# Altium schematic sheet Power Management.SchDoc
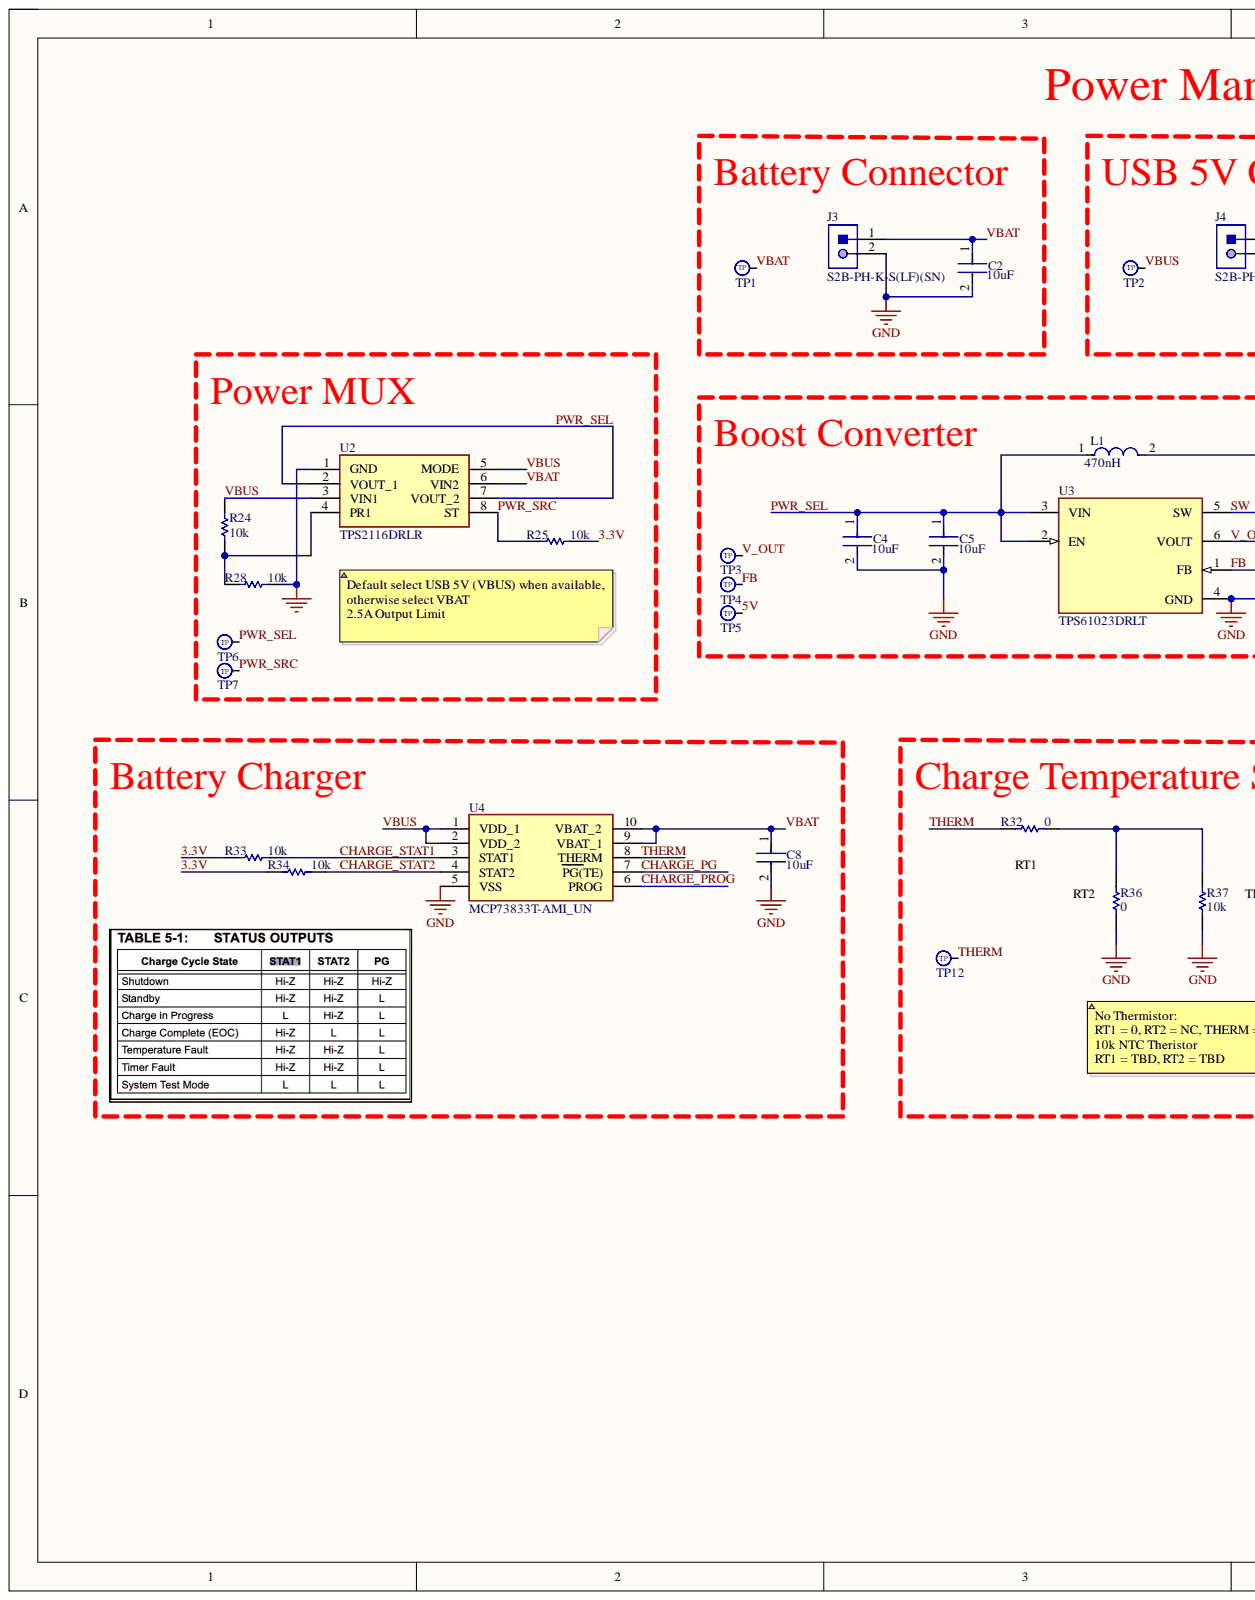
[diagram p0: Power Management.SchDoc, left]
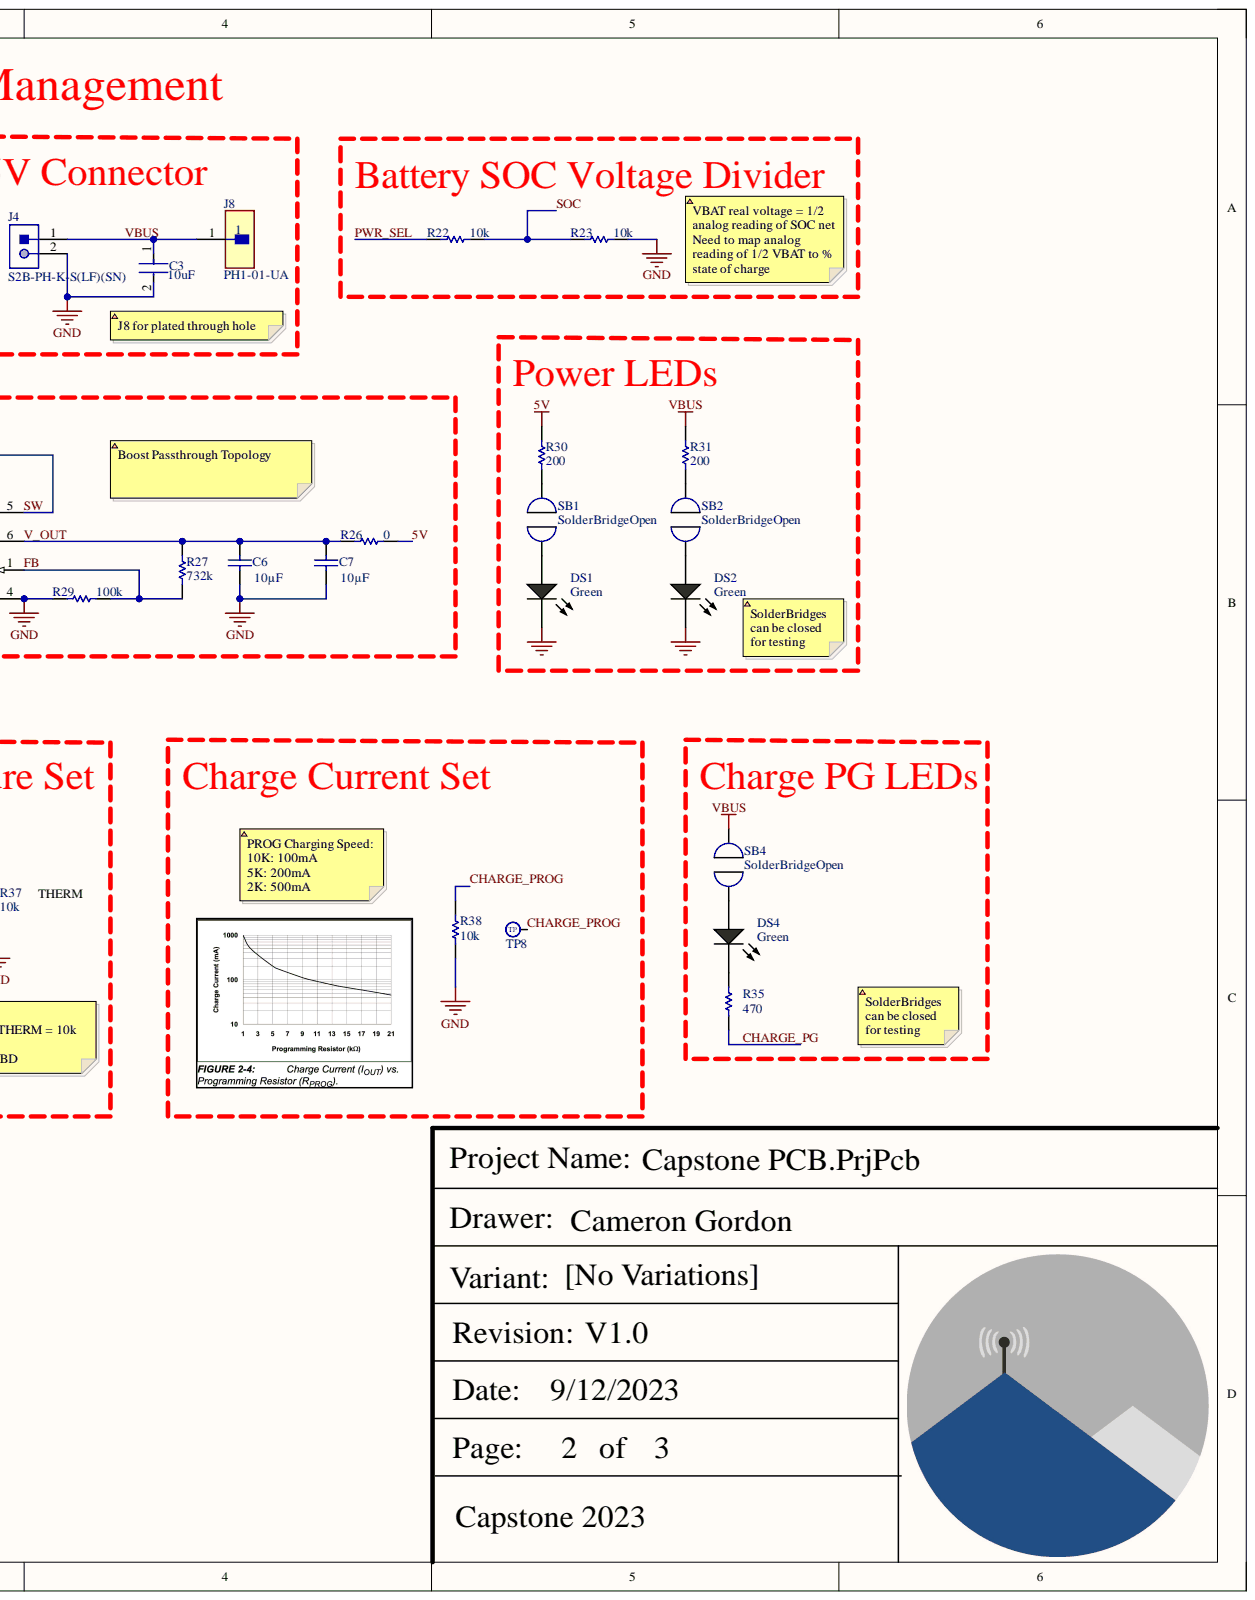
[diagram p1: Power Management.SchDoc, right]

## Parts
C2  value 10uF  Capacitance: 10uF  [FP-C0805C-DG-MFG]  (hidden comment: C0805C106K8PACTU)
C3  value 10uF  Capacitance: 10uF  [FP-C0805C-DG-MFG]  (hidden comment: C0805C106K8PACTU)
C4  value 10uF  Capacitance: 10uF  [FP-C0805C-DG-MFG]  (hidden comment: C0805C106K8PACTU)
C5  value 10uF  Capacitance: 10uF  [FP-C0805C-DG-MFG]  (hidden comment: C0805C106K8PACTU)
C6  Capacitance: 10µF  [CAPC3216X180X55LL20T25]  (hidden comment: GRM31CR71C106KAC7L)
C7  Capacitance: 10µF  [CAPC3216X180X55LL20T25]  (hidden comment: GRM31CR71C106KAC7L)
C8  value 10uF  Capacitance: 10uF  [FP-C0805C-DG-MFG]  (hidden comment: C0805C106K8PACTU)
DS1  Color: Green  [LUMX-SML-LXT0805_V]  (hidden comment: SML-LXT0805GW-TR)
DS2  Color: Green  [LUMX-SML-LXT0805_V]  (hidden comment: SML-LXT0805GW-TR)
DS4  Color: Green  [LUMX-SML-LXT0805_V]  (hidden comment: SML-LXT0805GW-TR)
J3  S2B-PH-K-S(LF)(SN)  [JST-S2B-PH-K-S_V]
J4  S2B-PH-K-S(LF)(SN)  [JST-S2B-PH-K-S_V]
J8  PH1-01-UA  [FP-PH1-01-UA-MFG]
L1  Inductance: 470nH  [FP-IHLP-1212AE-11-MFG]  (hidden comment: IHLP1212AEERR47M11)
R22  Resistance: 10k  [RESC1609X55X30LL15T15]  (hidden comment: ERJ3EKF1002V)
R23  Resistance: 10k  [RESC1609X55X30LL15T15]  (hidden comment: ERJ3EKF1002V)
R24  Resistance: 10k  [RESC1609X55X30LL15T15]  (hidden comment: ERJ3EKF1002V)
R25  Resistance: 10k  [RESC1609X55X30LL15T15]  (hidden comment: ERJ3EKF1002V)
R26  RC0603JR-070RL  [RESC1608X55X25ML10T15]  (value not drawn)
R27  value 732k  [RESC1608X55X30LL15T15]  (hidden comment: ERJ-3EKF5232V)
R28  Resistance: 10k  [RESC1609X55X30LL15T15]  (hidden comment: ERJ3EKF1002V)
R29  value 100k  [RESC1608X55X30ML15T15]  (hidden comment: ERJ-3EKF1003V)
R30  value 200  [RESC2013X60X35ML10T20]  (hidden comment: RC0805JR-07200RL)
R31  value 200  [RESC2013X60X35ML10T20]  (hidden comment: RC0805JR-07200RL)
R32  RC0603JR-070RL  [RESC1608X55X25ML10T15]  (value not drawn)
R33  Resistance: 10k  [RESC1609X55X30LL15T15]  (hidden comment: ERJ3EKF1002V)
R34  Resistance: 10k  [RESC1609X55X30LL15T15]  (hidden comment: ERJ3EKF1002V)
R35  value 470  [RESC1608X55X30NL15T15]  (hidden comment: ERJ-3GEYJ471V)
R36  RC0603JR-070RL  [RESC1608X55X25ML10T15]  (value not drawn)
R37  Resistance: 10k  [RESC1609X55X30LL15T15]  (hidden comment: ERJ3EKF1002V)
R38  Resistance: 10k  [RESC1609X55X30LL15T15]  (hidden comment: ERJ3EKF1002V)
SB1  SolderBridgeOpen  [SOLDERBRIDGEOPEN]
SB2  SolderBridgeOpen  [SOLDERBRIDGEOPEN]
SB4  SolderBridgeOpen  [SOLDERBRIDGEOPEN]
TP1  0.040" Circular Test Point  [40 mil Circular Test Point]  (value not drawn)
TP2  0.040" Circular Test Point  [40 mil Circular Test Point]  (value not drawn)
TP3  0.040" Circular Test Point  [40 mil Circular Test Point]  (value not drawn)
TP4  0.040" Circular Test Point  [40 mil Circular Test Point]  (value not drawn)
TP5  0.040" Circular Test Point  [40 mil Circular Test Point]  (value not drawn)
TP6  0.040" Circular Test Point  [40 mil Circular Test Point]  (value not drawn)
TP7  0.040" Circular Test Point  [40 mil Circular Test Point]  (value not drawn)
TP8  0.040" Circular Test Point  [40 mil Circular Test Point]  (value not drawn)
TP12  0.040" Circular Test Point  [40 mil Circular Test Point]  (value not drawn)
U2  TPS2116DRLR  [SOTFL50P160X60-8N]
U3  TPS61023DRLT  [FP-DRL0006A-MFG]
U4  MCP73833T-AMI_UN  [SOP50P490X110-10N]

## Nets
GND: C2.2 C3.2 C4.2 C5.2 C6.1 C7.1 C8.2 DS1.2 DS2.2 J3.2 J4.2 R23.2 R28.2 R29.1 R36.2 R37.1 R38.1 U2.1(GND) U3.4(GND) U4.5(VSS)
3.3V: R25.2 R33.1 R34.1
5V: R26.1 R30.2 TP5.1
CHARGE_PG: R35.1 U4.7(PG(TE))
CHARGE_PROG: R38.2 TP8.1 U4.6(PROG)
CHARGE_STAT1: R33.2 U4.3(STAT1)
CHARGE_STAT2: R34.2 U4.4(STAT2)
FB: R27.1 R29.2 TP4.1 U3.1(FB)
PWR_SEL: C4.1 C5.1 L1.1 R22.1 TP6.1 U2.2(VOUT_1) U2.7(VOUT_2) U3.2(EN) U3.3(VIN)
PWR_SRC: R25.1 TP7.1 U2.8(ST)
SOC: R22.2 R23.1
SW: L1.2 U3.5(SW)
THERM: R32.2 TP12.1 U4.8(THERM)
V_OUT: C6.2 C7.2 R26.2 R27.2 TP3.1 U3.6(VOUT)
VBAT: C2.1 C8.1 J3.1 TP1.1 U2.6(VIN2) U4.9(VBAT_1) U4.10(VBAT_2)
VBUS: C3.1 J4.1 J8.1 R24.2 R31.2 SB4.2 TP2.1 U2.3(VIN1) U2.5(MODE) U4.1(VDD_1) U4.2(VDD_2)
NetDS1_1: DS1.1 SB1.1
NetDS2_1: DS2.1 SB2.1
NetDS4_1: DS4.1 SB4.1
NetDS4_2: DS4.2 R35.2
NetR24_1: R24.1 R28.1 U2.4(PR1)
NetR30_1: R30.1 SB1.2
NetR31_1: R31.1 SB2.2
NetR32_1: R32.1 R36.1 R37.2

## Library data of the parts
C2-C5 C8 = CMP-2007-03243-2: CAP CER 10UF 10V X5R 0805; 3DS Part Number: 220-00001; Manufacturer: Kemet; Material: Ceramic; Temperature Coefficient: 15%; Tolerance: 10%; Automotive: No; Category: Cap; Component Kind: Standard; ComponentLink2Description: Datasheet; ComponentLink2URL: https://content.kemet.com/datasheets/KEM_C1006_X5R_SMD.pdf; Device Class L1: Passive Components; Device Class L2: Capacitors; Device Class L3: Ceramic Capacitors; Digikey Description: CAP CER 10UF 10V X5R 0805; Height: 1.4mm; Ipc Land Pattern Name: CAPC200125X125; Lead Free: Yes; Library Name: Altium Content Vault; Manufacturer Part Number: C0805C106K8PACTU; Mouser Description: CAP CER 10UF 10V X5R 0805; Octopart: https://octopart.com/c0805c106k8pactu-kemet-98697; Package: C0805C-DG; RoHS: TRUE; Temperature: -55°C to +85°C; Temperature Characteristic: X5R; Temperature Range High: +85°C; Temperature Range Low: -55°C; Voltage: 10V; Voltage Rating: 10V
C6 C7 = CMP-2000-06352-1: Chip Capacitor, 10 uF, +/- 10%, 16 V, -55 to 125 degC, 1206 (3216 Metric), RoHS, Tape and Reel; Manufacturer: Murata; Catalog Drawings: GRMSeries_1206_1.6; Featured Product: GA3SafetyRecognizedCapsandHi-CapMLCC; Online Catalog: GRMSeries; Other Names: 490-3911-6; Product Training Modules: High-CapMultilayerCeramicCapacitors; Temperature Coefficient: X7R; Voltage - Rated: 16V; Applications: GeneralPurpose; Category: Capacitors; Component Kind: Standard; Datasheet URL: http://search.murata.co.jp/Ceramy/image/img/A01X/G101/ENG/GRM31CR71C106KAC7-01.pdf; Datasheet Version: 03/2016; Family: CeramicCapacitors; Features: -; Height - Seated (Max): -; Lead Spacing: -; Lead Style: -; Library Name: Altium Content Vault; Manufacturer URL: http://www.murata.com/; Mounting Technology: SurfaceMount; Mounting Type: SurfaceMount,MLCC; Operating Temperature: -55°C~125°C; Package / Case: 1206(3216Metric); Package Description: 2-Pin Surface Mount Device, Body 3.2 x 1.6 mm; Package Reference: 1206; Packaging: Digi-Reel®; Ratings: -; Series: GRM; Size / Dimension: 0.126"Lx0.063"W(3.20mmx1.60mm); Standard Package: 1; Thickness (Max): 0.071"(1.80mm); Tolerance: ±10%
DS1 DS2 DS4 = CMP-1724-00001-1: LED, GREEN, 565 nm, 2 V, -25 to 80 degC, 2-Pin SMD, RoHS, Tape and Reel; Case\/Package: 0805; Case Code (Imperial): 0805; Case Code (Metric): 2012; Component Kind: Standard; ComponentLink1Description: Manufacturer URL; ComponentLink1URL: http://www.lumexinstruments.com/; ComponentLink2Description: Datasheet; ComponentLink2URL: http://www.lumex.com/content/files/ProductAttachment/SML-LXT0805GW-TR.pdf; DatasheetVersion: Rev. F, 09/2009; Length: 2 mm; Library Name: Altium Content Vault; Max Operating Temperature: 85 degC; Min Operating Temperature: -25 degC; Mount: Surface Mount; Mounting Technology: Surface Mount; Package Quantity: 4000; PackageDescription: 2-Pin Surface Mount Device, Body 2 x 1.25 mm; PackageReference: SML-LXT0805; Packaging: Tape and Reel; REACH SVHC: No SVHC; RoHSCompliant: true; Width: 1.25 mm
J3 J4 = CMP-1755-00004-1: Male Header, Pitch 2 mm, 1 x 2 Position, Height 4.8 mm, Tail Length 3.4 mm, -25 to 85 degC, RoHS, Bulk; Capacitance: 10 uF; Case\Package: Radial; Tolerance: 20%; Voltage Rating: 50 V; Component Kind: Standard; ComponentLink1Description: Datasheet; ComponentLink1URL: http://www.jst-mfg.com/product/pdf/eng/ePH.pdf; DatasheetVersion: Dec-12; Diameter: 5 mm; Height: 11 mm; Lead Pitch: 2 mm; Length: 11 mm; Library Name: Altium Content Vault; Max Operating Temperature: 85 degC; Min Operating Temperature: -40 degC; Mount: Through Hole; Package Quantity: 200; PackageDescription: Male Header, Pitch 2 mm, 1 x 2 Position, Height 4.8 mm, Tail Length 3.4 mm; PackageReference: S2B-PH-K-S; Packaging: Bulk; Pins: 2; Radiation Hardening: No; REACH SVHC: No SVHC; RoHSCompliant: true; Voltage Rating (DC): 50 V
J8 = CMP-42765-000003-1: CONN HEADER VERT 1POS 2.54MM; Number of Positions: 1; Voltage Rating (AC): 250V; Component Kind: Standard; Connector Type: Header; Contact Finish - Mating: GOLD; Contact Material: Brass; Contact Shape: Square; Contact Type: Male Pin; Fastening Type: Pull; Flammability Rating: UL94 V-0; Insulation Color: Black; Insulation Material: PBT; Lead Free: Lead Free; Library Name: Altium Content Vault; Max Operating Temperature: 105°C; Min Operating Temperature: -40°C; Mount: Through Hole; Number of Rows: 1; Packaging: Bulk; Series: PH1; Termination: Solder
L1 = CMP-02424-000856-1: FIXED IND 470NH 6.7A 15 MOHM SMD; Core Material: Ferrite; DC Resistance (DCR): 15mR; Inductance Tolerance: 20%; Self Resonant Frequency: 1MHz; Shielding: Shielded; Test Frequency: 100kHz; Case Code (Imperial): 1212; Case/Package: Nonstandard; Component Kind: Standard; Current Rating: 6.7A; Depth: 3.2mm; Height: 1.2mm; Height - Seated (Max): 0.059inch; Lead Free: Lead Free; Length: 0.144inch; Library Name: Altium Content Vault; Max DC Current: 6.7A; Max Operating Temperature: 125°C; Military Standard: Not; Min Operating Temperature: -55°C; Mount: Surface Mount; Number of Pins: 2; Package Quantity: 3000; Packaging: Cut Tape; Radiation Hardening: No; Rated Current-Max: 6.7A; RMS Current (Irms): 6.7A; RoHS Compliant: Yes; Saturation Current: 6.7A; Series: IHLP-1212AE-11; Termination: SMD/SMT; Tolerance: 20%; Width: 0.118inch
R22-R25 R28 R33 R34 R37 R38 = CMP-1012-00623-3: Chip Resistor, 10 KOhm, +/- 1%, 0.1 W, -55 to 155 degC, 0603 (1608 Metric), RoHS, Tape and Reel; Manufacturer: Panasonic; Case\/Package: 0603; Max Power Dissipation: 100mW; Temperature Coefficient: 100ppm\/degreesC; Voltage Rating: 75V; Case Code (Imperial): 0603; Case Code (Metric): 1608; Component Kind: Standard; Composition: ThickFilm; Contact Plating: Tin; Datasheet URL: https://industrial.panasonic.com/cdbs/www-data/pdf/RDA0000/AOA0000C304.pdf; Datasheet Version: 02/2017; Depth: 0.8mm; Height: 0.45mm; Lead Free: LeadFree; Length: 1.6mm; Library Name: Altium Content Vault; Manufacturer URL: http://www.panasonic.com/; Max Operating Temperature: 155degC; Military Standard: Not; Min Operating Temperature: -55degC; Mount: SurfaceMount; Mounting Technology: SurfaceMount; Number of Pins: 2; Number of Terminations: 2; Package Description: 2-Pin Surface Mount Device, Body 1.6 x 0.85 mm; Package Quantity: 1; Package Reference: 603; Packaging: TapeandReel; Power Rating: 100mW; Radiation Hardening: No; REACH SVHC: NoSVHC; RoHSCompliant: true; Termination: SMD/SMT; Tolerance: 1%; Voltage Rating (DC): 75V; Width: 0.031inch
R26 R32 R36 = CMP-2100-03667-1: Chip Resistor, 0 Ohm, +/- 5%, 0.1 W, -55 to 155 degC, 0603 (1608 Metric), RoHS, Tape and Reel; Manufacturer: Yageo; Power Rating: 100mW; Resistance: 0; Temperature Coefficient: 200ppm/degreesC; Voltage Rating: 50V; Case Code (Imperial): 0603; Case Code (Metric): 1608; Case/Package: 0603; Component Kind: Standard; Composition: ThickFilm; Contact Plating: Tin; Datasheet URL: http://www.yageo.com/NewPortal/yageodocoutput?fileName=/pdf/R-Chip/PYu-RC_Group_51_RoHS_L_5.pdf; Datasheet Version: Rev. 5, 10/2016; Depth: 0.8mm; Height: 0.45mm; Lead Free: LeadFree; Length: 1.6mm; Library Name: Altium Content Vault; Manufacturer URL: http://www.yageo.com/; Max Operating Temperature: 155degC; Military Standard: Not; Min Operating Temperature: -55degC; Number of Pins: 2; Package Description: 2-Pin Surface Mount Device, Body 1.6 x 0.8 mm; Package Quantity: 5000; Package Reference: 0603; Packaging: TapeandReel; Radiation Hardening: No; REACH SVHC: NoSVHC; RoHSCompliant: true; Termination: SMD/SMT; Tolerance: 5%; Voltage Rating (DC): 50V; Width: 0.8mm
R27 = CMP-2000-00551-1: Manufacturer: Panasonic; Component Kind: Standard; Library Name: Altium Content Vault; Part Number: ERJ-3EKF5232V
R29 = CMP-2000-00182-1: Manufacturer: Panasonic; Component Kind: Standard; Library Name: Altium Content Vault; Part Number: ERJ-3EKF1003V
R30 R31 = CMP-2001-01354-1: Manufacturer: Yageo; Component Kind: Standard; Library Name: Altium Content Vault; Part Number: RC0805JR-07200RL
R35 = CMP-2000-00795-1: Manufacturer: Panasonic; Component Kind: Standard; Library Name: Altium Content Vault; Part Number: ERJ-3GEYJ471V
TP1-TP8 TP12 = CMP-00017-2: Component Kind: Standard; Description: 0.040" Circular Test Point; Library Name: Northeastern Electric Racing
U2 = TPS2116DRLR: Integrated Circuit; Manufacturer_Name: Texas Instruments; Arrow Part Number: TPS2116DRLR; Arrow Price/Stock: https://www.arrow.com/en/products/tps2116drlr/texas-instruments?region=nac; Component Kind: Standard; Datasheet Link: https://www.ti.com/lit/gpn/tps2116; Height: 0.6mm; Manufacturer_Part_Number: TPS2116DRLR; Mouser Part Number: 595-TPS2116DRLR; Mouser Price/Stock: https://www.mouser.co.uk/ProductDetail/Texas-Instruments/TPS2116DRLR?qs=aP1CjGhiNiGo3XAyXcVPaQ%3D%3D
U3 = CMP-04918-000657-1: IC REG BOOST ADJ 3A SOT563; Component Kind: Standard; Library Name: Altium Content Vault
U4 = MCP73833T-AMI_UN: Integrated Circuit; Manufacturer_Name: Microchip; Manufacturer_Part_Number: MCP73833T-AMI/UN; Arrow Part Number: MCP73833T-AMI/UN; Arrow Price/Stock: https://www.arrow.com/en/products/mcp73833t-amiun/microchip-technology?region=nac; Component Kind: Standard; Datasheet Link: https://datasheet.datasheetarchive.com/originals/distributors/Datasheets-DGA15/727183.pdf; Height: 1.1mm; Mouser Part Number: 579-MCP73833T-AMI/UN; Mouser Price/Stock: https://www.mouser.co.uk/ProductDetail/Microchip-Technology/MCP73833T-AMI-UN?qs=Fxu3fLyJv8cZVyNfuXhl2Q%3D%3D
SB1 SB2 SB4 = SolderBridgeOpen: Component Kind: Standard; Library Name: SolderBridge.SchLib
